# Altium schematic sheet Voltage_Follower_PCB.SchDoc(Voltage_Follower_PCB)
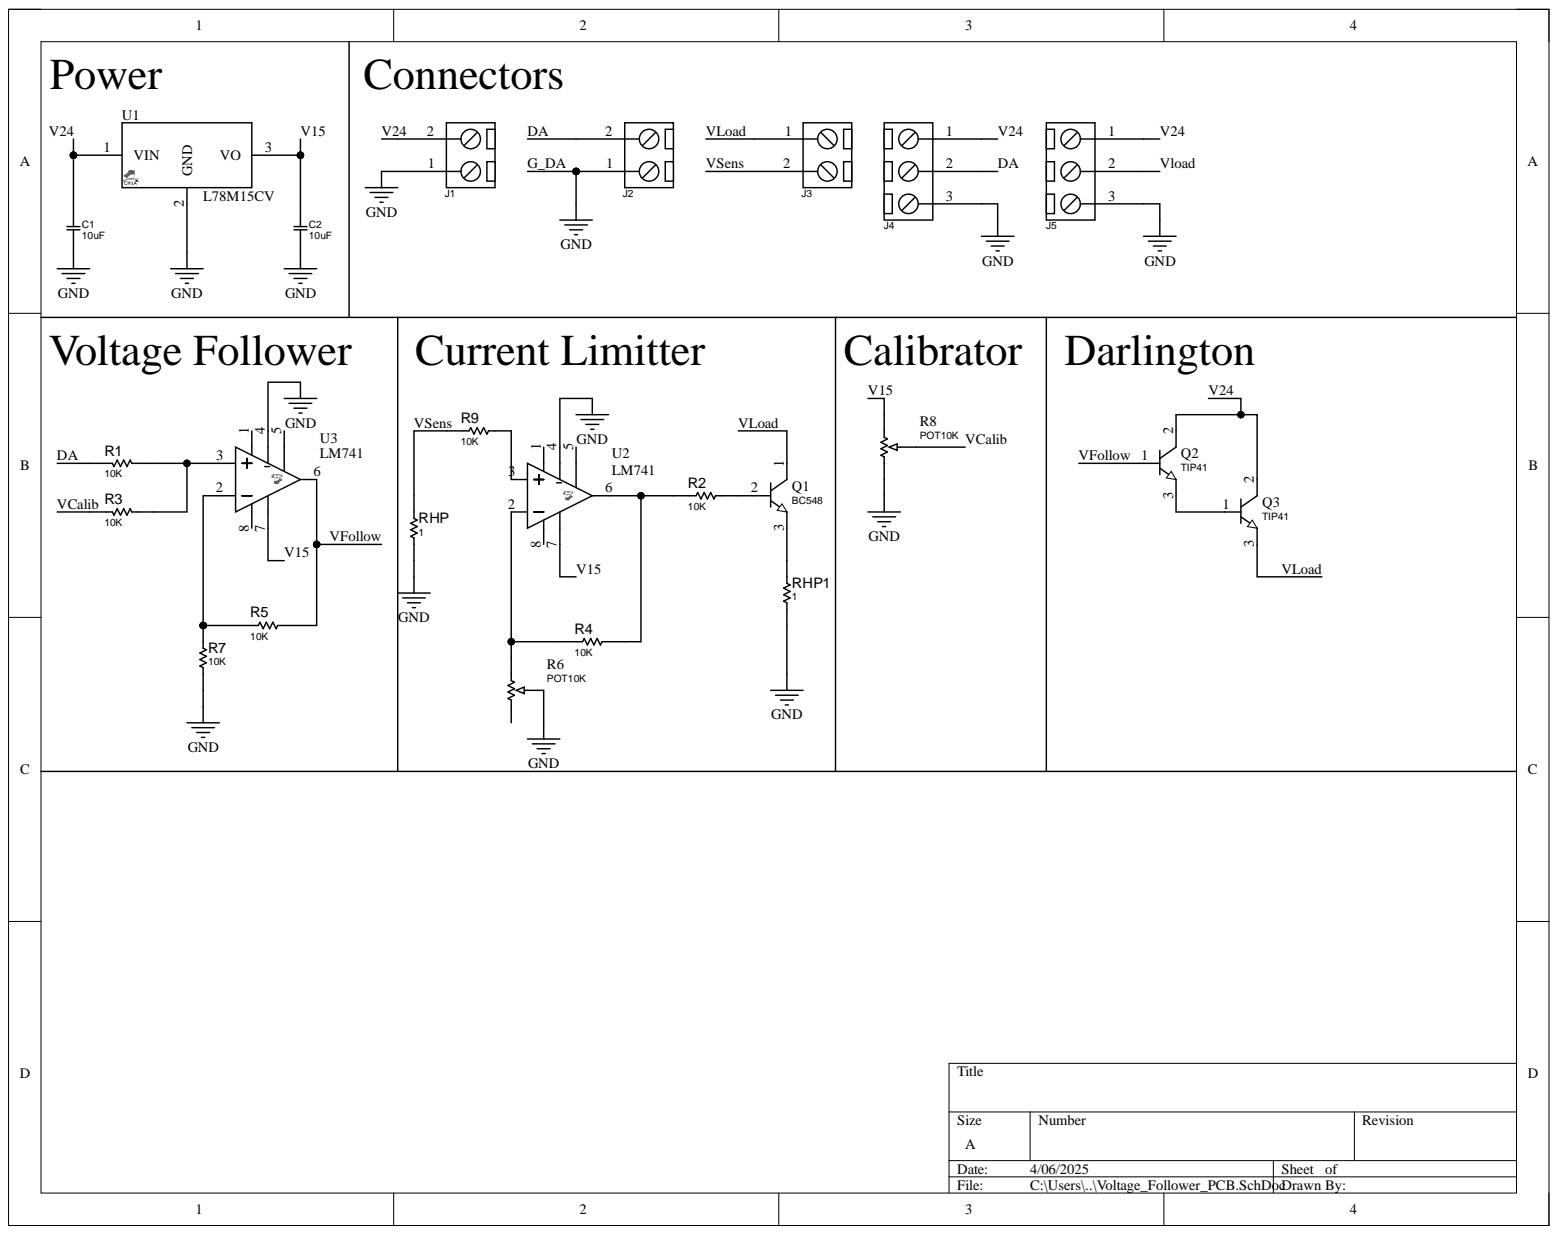
[diagram p0: Voltage_Follower_PCB.SchDoc]

## Parts
C1  10uF  [C_CERM_D3]
C2  10uF  [C_CERM_D3]
J1  CONN_TB2  [TBG_2]  (value not drawn)
J2  CONN_TB2  [TBG_2]  (value not drawn)
J3  CONN_TB2  [TBG_2]  (value not drawn)
J4  CONN_TB3  [TBG_3]  (value not drawn)
J5  CONN_TB3  [TBG_3]  (value not drawn)
Q1  BC548  [TO92_3]
Q2  TIP41  [TO220_3]
Q3  TIP41  [TO220_3]
R1  10K  [R025W_AX04]
R2  10K  [R025W_AX04]
R3  10K  [R025W_AX04]
R4  10K  [R025W_AX04]
R5  10K  [R025W_AX04]
R6  POT10K  [POT_3296W]  (value not drawn)
R7  10K  [R025W_AX04]
R8  POT10K  [POT_3296W]  (value not drawn)
R9  10K  [R025W_AX04]
RHP  1  [RWW5W]
RHP1  1  [RWW5W]
U1  L78M15CV  [TO220_3]
U2  LM741  [DIP_8]
U3  LM741  [DIP_8]

## Nets
DA: J2.2 J4.2 R1.1
G_DA: C1.1 C2.1 J1.1 J2.1 J4.3 J5.3 R6.2 R7.1 R8.1 RHP.1 RHP1.1 U1.2 U2.4 U3.4
V15: C2.2 R8.3 U1.3 U2.7 U3.7
V24: C1.2 J1.2 J4.1 J5.1 Q2.2 Q3.2 U1.1
VCalib: R3.1 R8.2
VFollow: Q2.1 R5.2 U3.6
Vload: J3.1 J5.2 Q1.1 Q3.3
VSens: J3.2 R9.1 RHP.2
NetQ1_2: Q1.2 R2.2
NetQ1_3: Q1.3 RHP1.2
NetQ2_3: Q2.3 Q3.1
NetR1_2: R1.2 R3.2 U3.3
NetR2_1: R2.1 R4.2 U2.6
NetR4_1: R4.1 R6.3 U2.2
NetR5_1: R5.1 R7.2 U3.2
NetR9_2: R9.2 U2.3

Not connected: R6 1; U2 1 5 8; U3 1 5 8

## Library data of the parts
C1 C2 = CAP_THD_CER: CAP, THD, CER MULTI LAYER,; DESC: CAP, CER MULTI LAYER,; MANF_P#: MANF_PART#; PARAM2: 50V; ASSEMBLY: ASSEMBLY; Component Kind: Standard; Library Name: passive_thd.SchLib; MANF: MANF; PRICE: 100= 1$; VALUE: 10uF
J1-J3 = CONN_TB2: CONN, TERMINAL BLOCK, 5.08mm pitch; Component Kind: Standard; Library Name: conn_terminalB.SchLib; VALUE: CONN_TB2
J4 J5 = CONN_TB3: CONN, TERMINAL BLOCK, 5.08mm pitch; Component Kind: Standard; Library Name: conn_terminalB.SchLib; VALUE: CONN_TB3
Q1 = NPN_BC548_TO92: BJT, NPN, 30v, 0.1A, THD, TO92; MANF: FAIRCHILD; PARAM2: 500mW; Component Kind: Standard; Library Name: transistor_bjt.SchLib; MANF_P#: BC548; PARAM: 30v, 0.1A; Simulation: NS3904; VALUE: BC548
Q2 Q3 = NPN_TIP41_TO220: BJT, NPN, 60v, 6A, THD, TO-220; MANF: ON; MANF_P#: TIP41A, TIP41B, TIP41C; PARAM: 60-100v, 6A; PARAM2: 65W; Component Kind: Standard; Library Name: transistor_bjt.SchLib; Simulation: NS3904; VALUE: TIP41
R1-R5 R7 R9 RHP RHP1 = RES_THD: RESISTOR, THD; DESC: DESCRIPTION; DIST#: MOUSER; MANF: KOA SPEER; MANF_P#: RK73H1ETTP4701F; PARAM: 0.063W; PARAM2: 1%; ASSEMBLY: ASSEMBLY; Component Kind: Standard; Library Name: passive_thd.SchLib; PRICE: 100= 0.5$
  R1-R5 R7 R9: VALUE: 10K
  RHP RHP1: VALUE: 1
R6 R8 = RES_THD_POT_3296W: RESISTOR, THD, Potentiometer; Component Kind: Standard; VALUE: POT10K; Library Name: resistor_pot_trimmer.SchLib
U1 = VREG_78M15_TO220: REG, Linear, Fixed, 15v, 1.5A, TO220; MANF: ST; Component Kind: Standard; Library Name: pwr_reg_linear_78xx79xx.SchLib; MANF_P#: L78M15CV; PARAM: 15v, 1.5A; PARAM2: PARAM2; VALUE: L78M15CV
U2 U3 = LM741_DIP8: AMP, General-Purpose; MANF: Texas Instruments; MANF_P#: LM741CN; Component Kind: Standard; Library Name: amp.SchLib; VALUE: LM741
